# Altium schematic sheet PowCarbinBoard_General.SchDoc
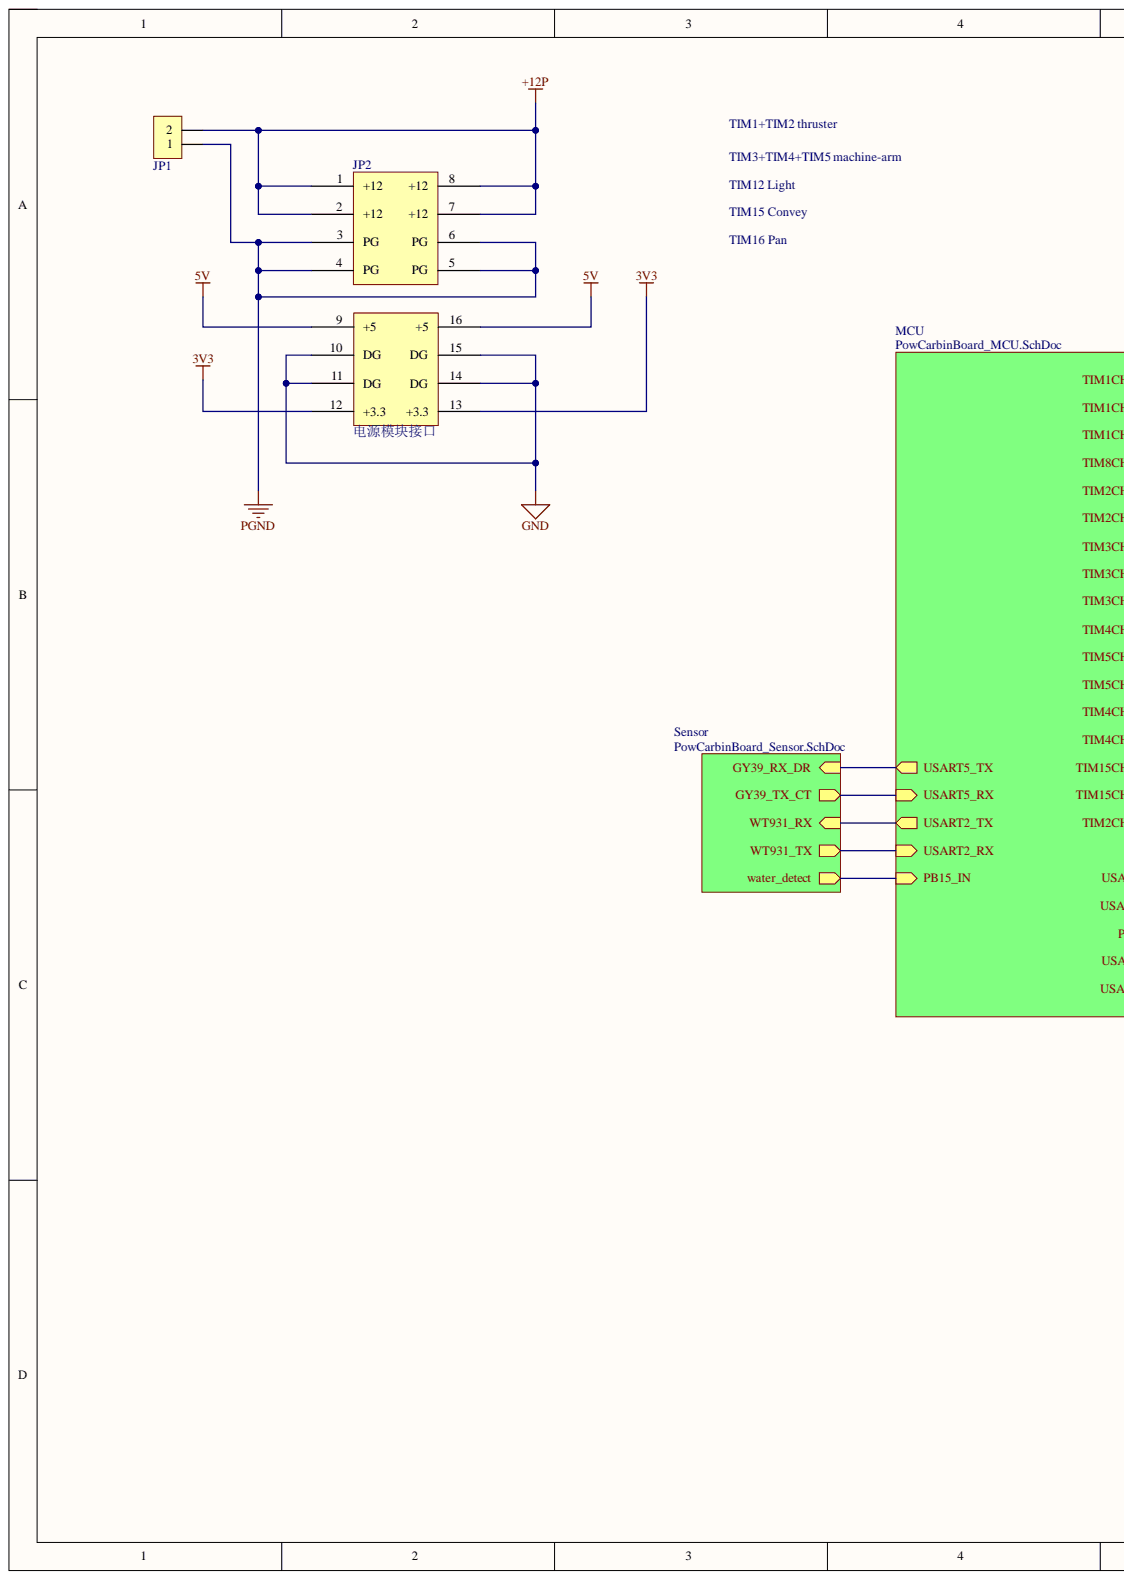
[diagram p0: PowCarbinBoard_General.SchDoc, left]
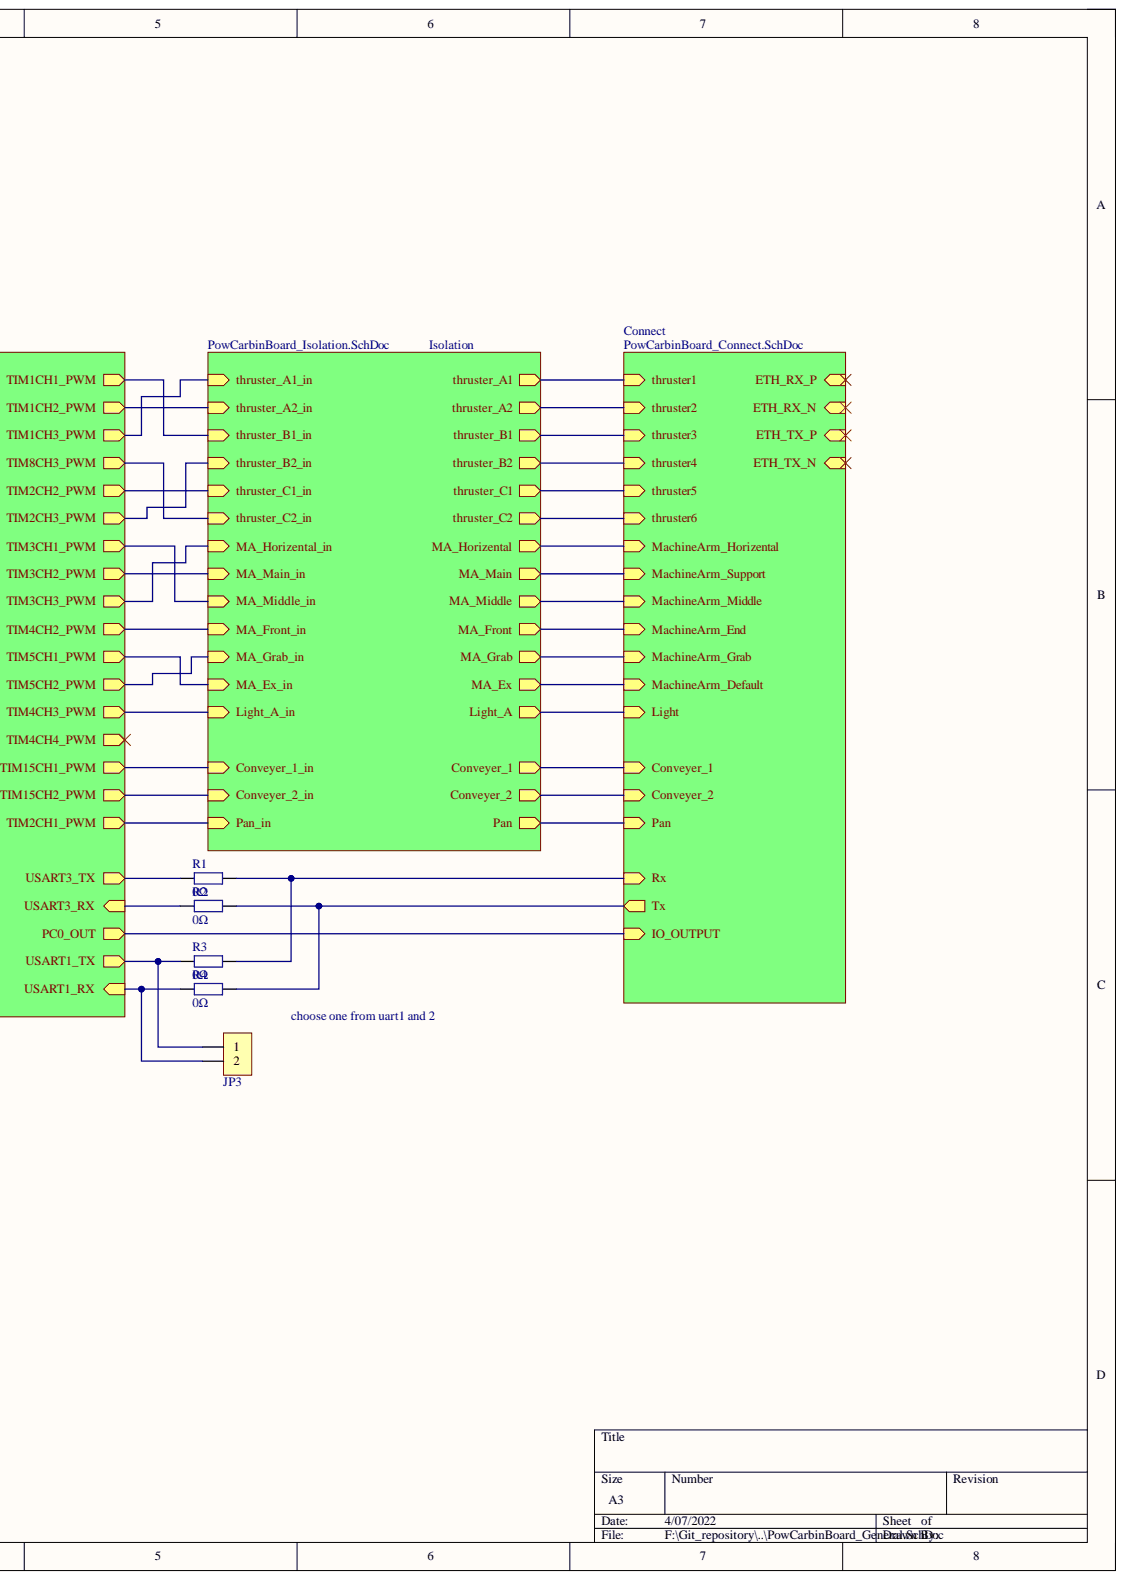
[diagram p1: PowCarbinBoard_General.SchDoc, right]

## Parts
JP1  HDR-1X2  [KF128-5.08-2P]  (value not drawn)
JP2  电源模块接口  [排针-座 2*4]
JP3  HDR-1X2  [HDR2.54-LI-2P]  (value not drawn)
R1  0Ω  [R 0603_L]
R2  0Ω  [R 0603_L]
R3  0Ω  [R 0603_L]
R4  0Ω  [R 0603_L]

## Nets
3V3: JP2.12(+3.3) JP2.13(+3.3)
5V: JP2.9(+5) JP2.16(+5)
+12P: JP1.2 JP2.1(+12) JP2.2(+12) JP2.7(+12) JP2.8(+12)
GND: JP2.10(DG) JP2.11(DG) JP2.14(DG) JP2.15(DG)
PGND: JP1.1 JP2.3(PG) JP2.4(PG) JP2.5(PG) JP2.6(PG)
NetJP3_1: JP3.1 R3.1
NetJP3_2: JP3.2 R4.1
NetR1_1: R1.1
NetR1_2: R1.2 R3.2
NetR2_1: R2.1
NetR2_2: R2.2 R4.2
Conveyer_1:
Conveyer_1_in:
Conveyer_2:
Conveyer_2_in:
ETH_RX_N:
ETH_RX_P:
ETH_TX_N:
ETH_TX_P:
GY39_RX_DR:
GY39_TX_CT:
IO_OUTPUT:
Light:
Light_A_in:
MA_Ex:
MA_Ex_in:
MA_Front:
MA_Front_in:
MA_Grab:
MA_Grab_in:
MA_Horizental:
MA_Horizental_in:
MA_Main:
MA_Main_in:
MA_Middle:
MA_Middle_in:
Pan:
Pan_in:
PB15_IN:
thruster1:
thruster2:
thruster3:
thruster4:
thruster5:
thruster6:
thruster_A1_in:
thruster_A2_in:
thruster_B1_in:
thruster_B2_in:
thruster_C1_in:
thruster_C2_in:
TIM4CH4_PWM:
USART2_RX:
USART2_TX:

## Library data of the parts
JP1 JP3 = Header 2: 2P接插件; 版权归属: 源创客; BOM名称: 2P接插件; Component Kind: Standard; 学习资源: www.ooocke.com
  JP1: Library Name: 4.10 - 接插件-脚距5.08.SchLib
  JP3: Library Name: 4.5   - 接插件-脚距2.54.SchLib
R1-R4 = R: 贴片电阻; 版权归属: 源创客; BOM名称: 贴片电阻; Component Kind: Standard; Library Name: 3.1   - 电阻-电容-电感.SchLib; 学习资源: www.ooocke.com
JP2 = 电源模块接口: Component Kind: Standard; Library Name: MySchLib.SchLib
